# Altium schematic sheet MIPI_CSI.SchDoc(MIPI_CSI)
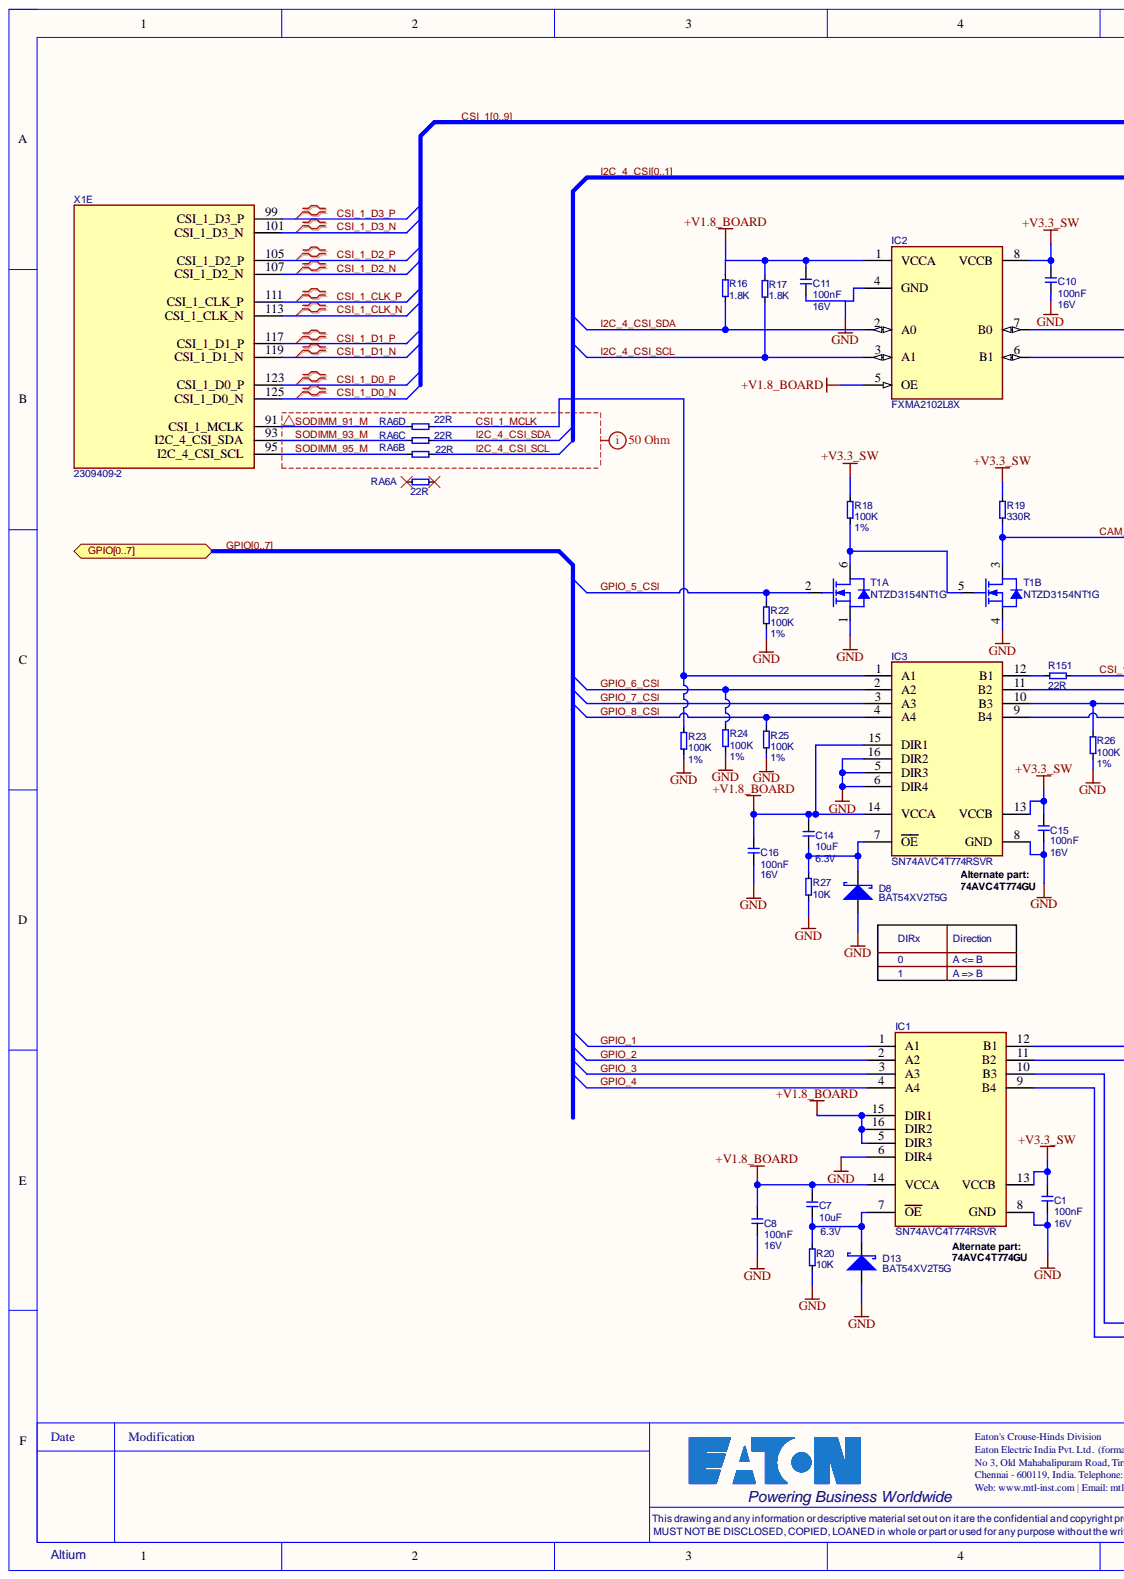
[diagram p0: MIPI_CSI.SchDoc, left]
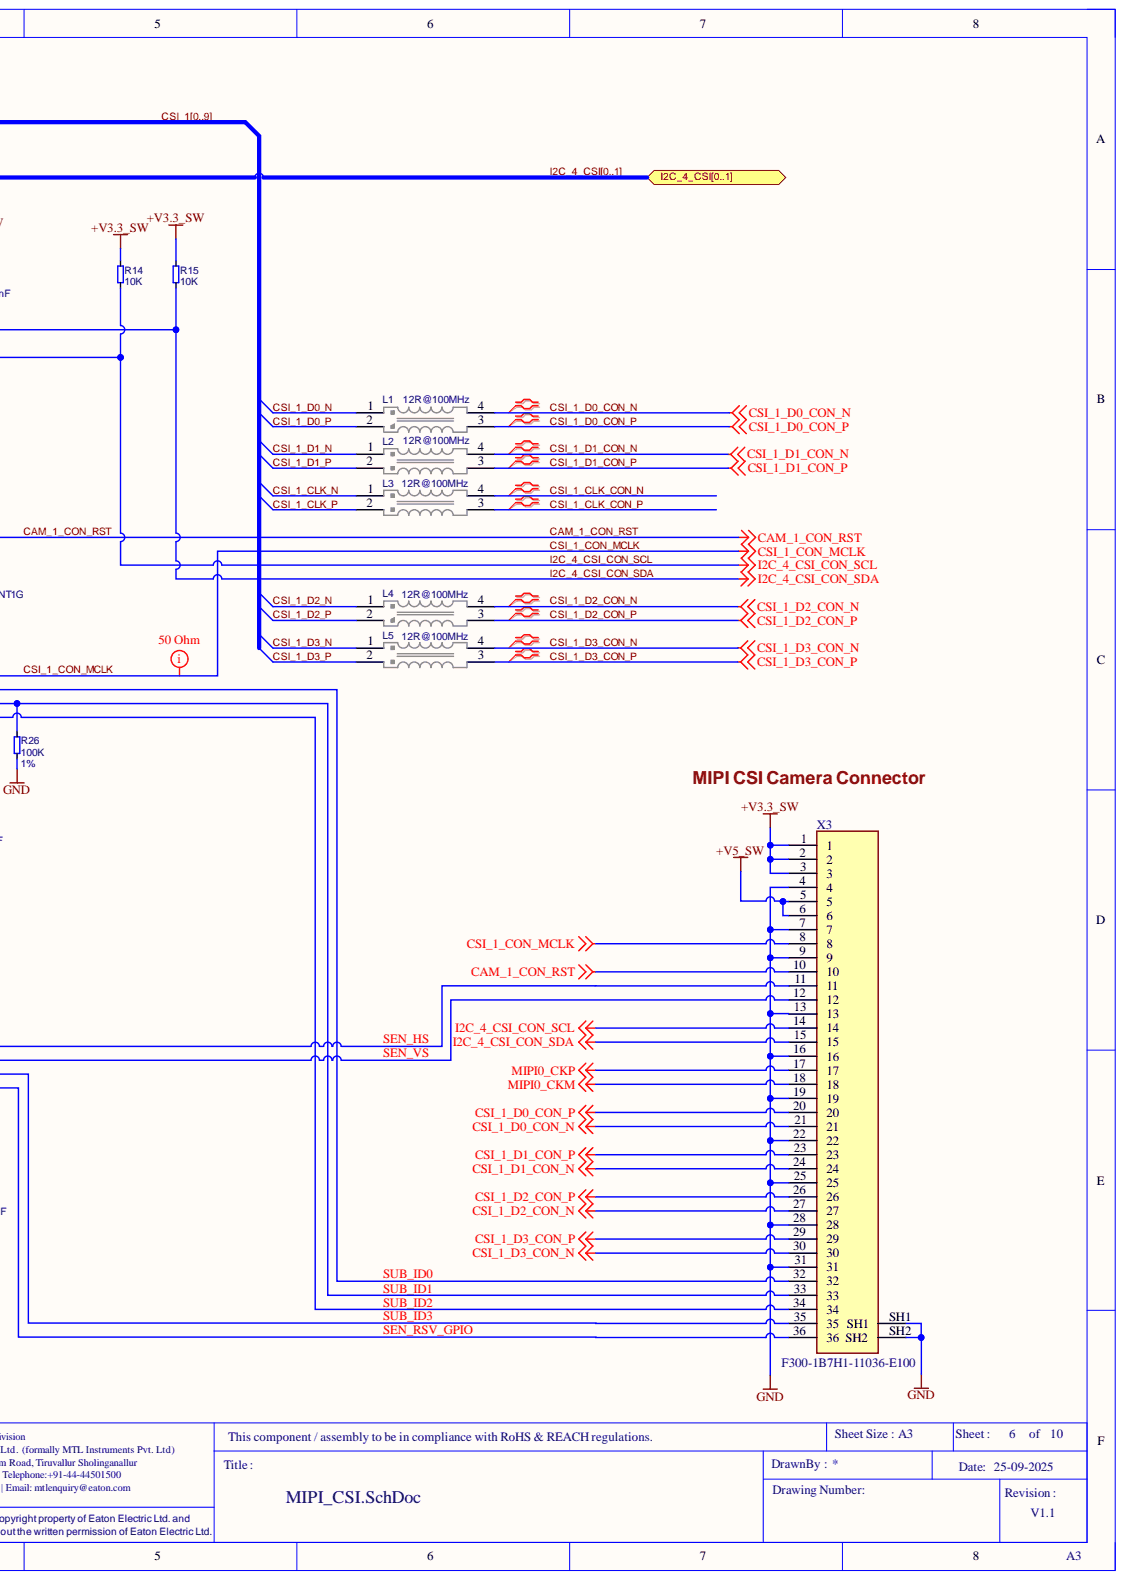
[diagram p1: MIPI_CSI.SchDoc, right]

## Parts
C1  100nF  Rating: 16V  [CAPC1005X60N]
C7  10uF  Rating: 6.3V  [CAPC1005X60N]
C8  100nF  Rating: 16V  [CAPC1005X60N]
C10  100nF  Rating: 16V  [CAPC1005X60N]
C11  100nF  Rating: 16V  [CAPC1005X60N]
C14  10uF  Rating: 6.3V  [CAPC1005X60N]
C15  100nF  Rating: 16V  [CAPC1005X60N]
C16  100nF  Rating: 16V  [CAPC1005X60N]
D8  BAT54XV2T5G  [SOD-523]
D13  BAT54XV2T5G  [SOD-523]
IC1  SN74AVC4T774RSVR  [IC-UQFN40P180X210X55-16N]
IC2  FXMA2102L8X  [IC-MicroPak50P160X2160X55-8N]
IC3  SN74AVC4T774RSVR  [IC-UQFN40P180X210X55-16N]
L1  12R@100MHz  [T_Murata_DLM0NSB120HY2D]
L2  12R@100MHz  [T_Murata_DLM0NSB120HY2D]
L3  12R@100MHz  [T_Murata_DLM0NSB120HY2D]
L4  12R@100MHz  [T_Murata_DLM0NSB120HY2D]
L5  12R@100MHz  [T_Murata_DLM0NSB120HY2D]
R14  10K  [RESC1005X40N]
R15  10K  [RESC1005X40N]
R16  1.8K  [RESC1005X40N]
R17  1.8K  [RESC1005X40N]
R18  100K  Tolerance: 1%  [RESC1005X40N]
R19  330R  [RESC1005X40N]
R20  10K  [RESC1005X40N]
R22  100K  Tolerance: 1%  [RESC1005X40N]
R23  100K  Tolerance: 1%  [RESC1005X40N]
R24  100K  Tolerance: 1%  [RESC1005X40N]
R25  100K  Tolerance: 1%  [RESC1005X40N]
R26  100K  Tolerance: 1%  [RESC1005X40N]
R27  10K  [RESC1005X40N]
R151  22R  [RESC1005X40N]
RA6  22R  [T_Resistor_Array_0402_X4]  (parts A,B,C,D)
T1  Manufacturer Part No.: NTZD3154NT1G  [SOTFL50P160X60-6N]  (hidden comment: T-ONSemi-NTZD3154NT1G)  (parts A,B)
X1  2309409-2  [CON-TE-2309409-2]  (part E)
X3  CONN FFC BOTTOM 36POS 0.5MM R/A  [AMPHENOL_F300-1B7H1-11036-E100]  (value not drawn)

## Ports
GPIO0, GPIO1, GPIO2, GPIO3, GPIO4, GPIO5, GPIO6, GPIO7, GPIO[0..7], I2C_4_CSI0, I2C_4_CSI1, I2C_4_CSI[0..1]

## Nets
+V1.8_BOARD: C7.2 C8.2 C11.2 C14.2 C16.2 IC1.5(DIR3) IC1.14(VCCA) IC1.15(DIR1) IC1.16(DIR2) IC2.1(VCCA) IC2.5(OE) IC3.14(VCCA) IC3.15(DIR1) R16.1 R17.1
+V3.3_SW: C1.2 C10.2 C15.2 IC1.13(VCCB) IC2.8(VCCB) IC3.13(VCCB) R14.2 R15.2 R18.2 R19.2 X3.1 X3.2 X3.3
+V5_SW: X3.5 X3.6
GND: C1.1 C8.1 C10.1 C11.1 C15.1 C16.1 D8.A D13.A IC1.6(DIR4) IC1.8(GND) IC2.4(GND) IC3.5(DIR3) IC3.6(DIR4) IC3.8(GND) IC3.16(DIR2) R20.1 R22.1 R23.2 R24.2 R25.2 R26.1 R27.1 T1.1 T1.4 X3.4 X3.7 X3.9 X3.13 X3.16 X3.19 X3.22 X3.25 X3.28 X3.31 X3.SH1 X3.SH2
MIPI0_CKM: X3.18
MIPI0_CKP: X3.17
CAM_1_CON_RST: R19.1 T1.3 X3.10
CSI_1_CLK_CON_N: L3.4
CSI_1_CLK_CON_P: L3.3
CSI_1_CLK_N: L3.1 X1.113(CSI_1_CLK_N)
CSI_1_CLK_P: L3.2 X1.111(CSI_1_CLK_P)
CSI_1_CON_MCLK: R151.2 X3.8
CSI_1_D0_CON_N: L1.4 X3.21
CSI_1_D0_CON_P: L1.3 X3.20
CSI_1_D0_N: L1.1 X1.125(CSI_1_D0_N)
CSI_1_D0_P: L1.2 X1.123(CSI_1_D0_P)
CSI_1_D1_CON_N: L2.4 X3.24
CSI_1_D1_CON_P: L2.3 X3.23
CSI_1_D1_N: L2.1 X1.119(CSI_1_D1_N)
CSI_1_D1_P: L2.2 X1.117(CSI_1_D1_P)
CSI_1_D2_CON_N: L4.4 X3.27
CSI_1_D2_CON_P: L4.3 X3.26
CSI_1_D2_N: L4.1 X1.107(CSI_1_D2_N)
CSI_1_D2_P: L4.2 X1.105(CSI_1_D2_P)
CSI_1_D3_CON_N: L5.4 X3.30
CSI_1_D3_CON_P: L5.3 X3.29
CSI_1_D3_N: L5.1 X1.101(CSI_1_D3_N)
CSI_1_D3_P: L5.2 X1.99(CSI_1_D3_P)
CSI_1_MCLK: IC3.1(A1) R23.1 RA6.8
GPIO_1: IC1.1(A1)
GPIO_2: IC1.2(A2)
GPIO_3: IC1.3(A3)
GPIO_4: IC1.4(A4)
GPIO_5_CSI: R22.2 T1.2
GPIO_6_CSI: IC3.2(A2) R24.1
GPIO_7_CSI: IC3.3(A3)
GPIO_8_CSI: IC3.4(A4) R25.1
I2C_4_CSI_CON_SCL: IC2.6(B1) R14.1 X3.14
I2C_4_CSI_CON_SDA: IC2.7(B0) R15.1 X3.15
I2C_4_CSI_SCL: IC2.3(A1) R17.2 RA6.6
I2C_4_CSI_SDA: IC2.2(A0) R16.2 RA6.7
SEN_HS: IC1.12(B1) X3.11
SEN_RSV_GPIO: IC1.9(B4) X3.36
SEN_VS: IC1.11(B2) X3.12
SODIMM_91_M: RA6.4 X1.91(CSI_1_MCLK)
SODIMM_93_M: RA6.3 X1.93(I2C_4_CSI_SDA)
SODIMM_95_M: RA6.2 X1.95(I2C_4_CSI_SCL)
SUB_ID0: IC3.11(B2) X3.32
SUB_ID1: IC3.10(B3) R26.2 X3.33
SUB_ID2: IC3.9(B4) X3.34
SUB_ID3: IC1.10(B3) X3.35
NetC7_1: C7.1 D13.C IC1.7(OE) R20.2
NetC14_1: C14.1 D8.C IC3.7(OE) R27.2
NetIC3_12: IC3.12(B1) R151.1
NetR18_1: R18.1 T1.5 T1.6
CSI_10:  [also labelled CSI_1]
CSI_11:  [also labelled CSI_1]
CSI_12:  [also labelled CSI_1]
CSI_13:  [also labelled CSI_1]
CSI_14:  [also labelled CSI_1]
CSI_15:  [also labelled CSI_1]
CSI_16:  [also labelled CSI_1]
CSI_17:  [also labelled CSI_1]
CSI_18:  [also labelled CSI_1]
CSI_19:  [also labelled CSI_1]
GPIO0: ; port GPIO[0..7]  [also labelled GPIO]
GPIO1: ; port GPIO[0..7]  [also labelled GPIO]
GPIO2: ; port GPIO[0..7]  [also labelled GPIO]
GPIO3: ; port GPIO[0..7]  [also labelled GPIO]
GPIO4: ; port GPIO[0..7]  [also labelled GPIO]
GPIO5: ; port GPIO[0..7]  [also labelled GPIO]
GPIO6: ; port GPIO[0..7]  [also labelled GPIO]
GPIO7: ; port GPIO[0..7]  [also labelled GPIO]
I2C_4_CSI0: ; port I2C_4_CSI[0..1]  [also labelled I2C_4_CSI]
I2C_4_CSI1: ; port I2C_4_CSI[0..1]  [also labelled I2C_4_CSI]

Not connected: RA6 1 5

## Library data of the parts
C1 C8 C10 C11 C15 C16 = C-X7R-100nF-16V-10%-0402: Capacitor Ceramic X7R 100nF 16V 10% 0402; Manufacturer Name: KEMET; Manufacturer Part No.: C0402C104K4RACTU; Component Kind: Standard; Library Name: SoM_Camera_Encoder.SCHLIB; Tolerance: 10%; Type: X7R; Value: 100nF
C7 C14 = C-X5R-10u-6.3V-20%-0402: Capacitor Ceramic X5R 10uF 6.3V 20% 0402; Manufacturer Name: Samsung Electro-Mechanics; Manufacturer Part No.: CL05A106MQ5NUNC; Component Kind: Standard; Library Name: SoM_Camera_Encoder.SCHLIB; Tolerance: 20%; Type: X5R; Value: 10uF
D8 D13 = D-BAT54-SOD523: Schottky Barrier Rectifier; Manufacturer Name: On Semi; Component Kind: Standard; Manufacturer Part No.: BAT54XV2T5G; Library Name: Mallow.SchLib
IC1 IC3 = IC-TI-SN74AVC4T774RSVR: 4 Bit Bidirectional l Voltage Level Translator, Configurable Direction, UFQFN-16 package; Manufacturer Name: Texas Instruments; Component Kind: Standard; Manufacturer Part No.: SN74AVC4T774RSVR; Library Name: Mallow.SchLib
IC2 = IC-ONsemi-FXMA2102L8X: Voltage Level Translator for I2C Applications; Manufacturer Name: ONSemi; Component Kind: Standard; Manufacturer Part No.: FXMA2102L8X; Library Name: Mallow.SchLib
L1-L5 = L-Murata-DLM0NSB120HY2D-12R@100MHz: Common Mode Choke High Speed 12R 100mA; Manufacturer Name: Murata; Tolerance: 25%; Component Kind: Standard; Manufacturer Part No.: DLM0NSB120HY2D; Rating: 100mA; Value: 12R@100MHz; Library Name: Mallow.SchLib
R14 R15 R20 R27 = R-10K-63mW-5%-0402: Resistor 10 Kohm 63mW 5% 0402; Manufacturer Name: YAGEO; Manufacturer Part No.: RC0402JR-0710KL; Component Kind: Standard; Library Name: SoM_Camera_Encoder.SCHLIB; Rating: 63mW; Tolerance: 5%; Value: 10K
R16 R17 = R-1.8K-63mW-5%-0402: Resistor 1.8 Kohm 63mW 5% 0402; Manufacturer Name: KOA Speer Electronics, Inc.; Manufacturer Part No.: RK73B1ETTP182J; Component Kind: Standard; Library Name: SoM_Camera_Encoder.SCHLIB; Rating: 63mW; Tolerance: 5%; Value: 1.8K
R18 R22-R26 = R-100K-63mW-1%-0402: Resistor 100 Kohm 63mW 1% 0402; Manufacturer Name: Vishay Dale; Manufacturer Part No.: CRCW0402100KFKED; Component Kind: Standard; Library Name: SoM_Camera_Encoder.SCHLIB; Rating: 63mW; Value: 100K
R19 = R-330R-63mW-5%-0402: Resistor 330 ohm 63mW 5% 0402; Manufacturer Name: Stackpole Electronics Inc; Manufacturer Part No.: RMCF0402JT330R; Component Kind: Standard; Library Name: SoM_Camera_Encoder.SCHLIB; Rating: 63mW; Tolerance: 5%; Value: 330R
R151 = R-22R-63mW-5%-0402: Resistor 22 ohm 63mW 5% 0402; Manufacturer Name: YAGEO; Manufacturer Part No.: RC0402FR-0722RL; Component Kind: Standard; Library Name: SoM_Camera_Encoder.SCHLIB; Rating: 63mW; Tolerance: 5%; Value: 22R
RA6 = RA-22R-63mW-5%-0804-div: Resistor Array 22 R 63mW 5% 0804, Concave type; Component Kind: Standard; Library Name: SoM_Camera_Encoder.SCHLIB; Power Dissipation: 63mW; Tolerance: 5%; Value: 22R
T1 = T-ONSemi-NTZD3154NT1G: Dual N-Channel 20V (D-S)  MOSFET; Manufacturer Name: ONSemi; Component Kind: Standard; Library Name: Mallow.SchLib
X1 = CON-TE-2309409-2: DDR4 SODIMM Socket, 260 pins, 5.2mm(H), 0.5mm Pitch; Manufacturer Name: TE Connectivity; Component Kind: Standard; Manufacturer Part No.: 2309409-2; Package: DDR4-SODIMM-260; Temperature Range: -40C to +125C; Library Name: Mallow.SchLib
X3 = F300-1B7H1-11036-E100: 36 Position FFC, FPC Connector Contacts, Bottom 0.020" (0.50mm) Surface Mount, Right Angle; Manufacturer Name: Amphenol ICC / Aorora; Availability: Unavailable; Component Kind: Standard; Manufacturer Part No.: F300-1B7H1-11036-E100; Package: None; Price: None; Library Name: Mallow.SchLib
